# Altium schematic sheet CUK_3Ph_sig_conditioner.SchDoc
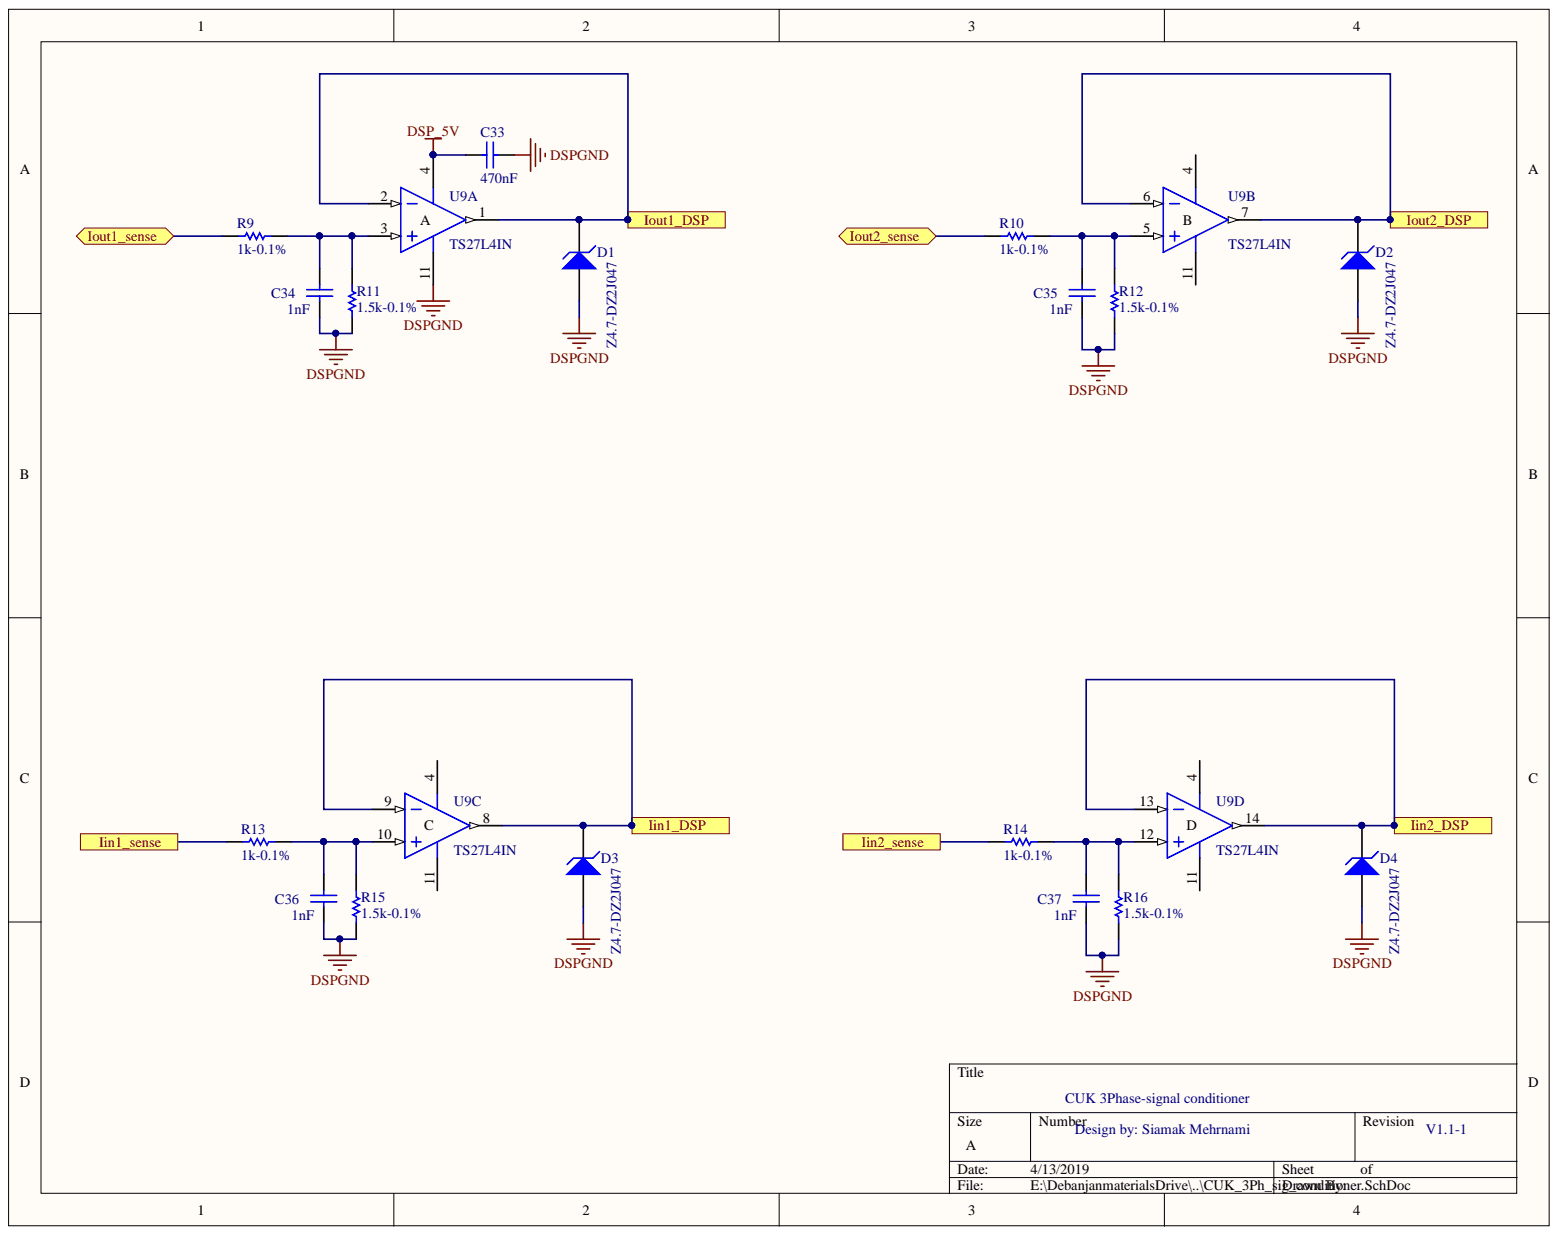
[diagram p0: CUK_3Ph_sig_conditioner.SchDoc]

## Parts
C33  value 470nF  [Capacitor 0805]  (hidden comment: Cap)
C34  value 1nF  [Capacitor 0805]  (hidden comment: Cap)
C35  value 1nF  [Capacitor 0805]  (hidden comment: Cap)
C36  value 1nF  [Capacitor 0805]  (hidden comment: Cap)
C37  value 1nF  [Capacitor 0805]  (hidden comment: Cap)
D1  Z4.7-DZ2J047  [Zener DZ2J047]
D2  Z4.7-DZ2J047  [Zener DZ2J047]
D3  Z4.7-DZ2J047  [Zener DZ2J047]
D4  Z4.7-DZ2J047  [Zener DZ2J047]
R9  value 1k-0.1%  [0805 RES]  (hidden comment: Res1)
R10  value 1k-0.1%  [0805 RES]  (hidden comment: Res1)
R11  value 1.5k-0.1%  [0805 RES]  (hidden comment: Res1)
R12  value 1.5k-0.1%  [0805 RES]  (hidden comment: Res1)
R13  value 1k-0.1%  [0805 RES]  (hidden comment: Res1)
R14  value 1k-0.1%  [0805 RES]  (hidden comment: Res1)
R15  value 1.5k-0.1%  [0805 RES]  (hidden comment: Res1)
R16  value 1.5k-0.1%  [0805 RES]  (hidden comment: Res1)
U9  TS27L4IN  [DIP14]  (parts A,B,C,D)

## Ports
Iin1_DSP, Iin1_sense, Iin2_DSP, Iin2_sense, Iout1_DSP, Iout1_sense, Iout2_DSP, Iout2_sense

## Nets
DSP_5V: C33.2 U9.4
DSPGND: C33.1 C34.1 C35.1 C36.1 C37.1 D1.1 D2.1 D3.1 D4.1 R11.1 R12.1 R15.1 R16.1 U9.11
Iin1_DSP: D3.2 U9.8 U9.9 ; port Iin1_DSP
Iin1_sense: R13.2 ; port Iin1_sense
Iin2_DSP: D4.2 U9.13 U9.14 ; port Iin2_DSP
Iin2_sense: R14.2 ; port Iin2_sense
Iout1_DSP: D1.2 U9.1 U9.2 ; port Iout1_DSP
Iout1_sense: R9.2 ; port Iout1_sense
Iout2_DSP: D2.2 U9.6 U9.7 ; port Iout2_DSP
Iout2_sense: R10.2 ; port Iout2_sense
NetC34_2: C34.2 R9.1 R11.2 U9.3
NetC35_2: C35.2 R10.1 R12.2 U9.5
NetC36_2: C36.2 R13.1 R15.2 U9.10
NetC37_2: C37.2 R14.1 R16.2 U9.12

## Library data of the parts
C33-C37 = Cap: Capacitor; Component Kind: Standard; LatestRevisionDate: 17-Jul-2002; LatestRevisionNote: Re-released for DXP Platform.; Library Name: Miscellaneous Devices.IntLib; PackageReference: RAD-0.3; Published: 8-Jun-2000; Signal Integrity: Cap; Simulation: CAP; Publisher: Altium Limited
D1-D4 = D Zener: Zener Diode; Component Kind: Standard; LatestRevisionDate: 17-Jul-2002; LatestRevisionNote: Re-released for DXP Platform.; Library Name: Miscellaneous Devices.IntLib; PackageReference: DIODE-0.7; Published: 8-Jun-2000; Signal Integrity: D Zener; Simulation: ZENER; Publisher: Altium Limited
R9-R16 = Res1: Resistor; Component Kind: Standard; LatestRevisionDate: 17-Jul-2002; LatestRevisionNote: Re-released for DXP Platform.; Library Name: Miscellaneous Devices.IntLib; PackageReference: AXIAL-0.3; Published: 8-Jun-2000; Signal Integrity: Res; Simulation: RESISTOR; Publisher: Altium Limited
U9 = TS27L4AMD: Very Low-Power CMOS Quad Operational Amplifier; Code_IPC: SOIC127P600-14; Component Kind: Standard; ComponentLink1Description: Manufacturer Link; ComponentLink1URL: http://www.st.com/; ComponentLink2Description: Datasheet; ComponentLink2URL: http://www.st.com/stonline/products/literature/ds/2305/ts27l4.pdf; DatasheetVersion: Nov-1998; LatestRevisionDate: 13-Apr-2006; LatestRevisionNote: IPC-7351 Footprint Added.; Library Name: ST Operational Amplifier.IntLib; PackageDescription: 14-Pin Small Outline Integrated Circuit 1.27 mm Pitch; PackageReference: SO14; PackageVersion: Sep-1998; PCB3D: SO14; Published: 24-Aug-2001; Publisher: Altium Limited
